# Altium schematic sheet miscellaneous.SchDoc
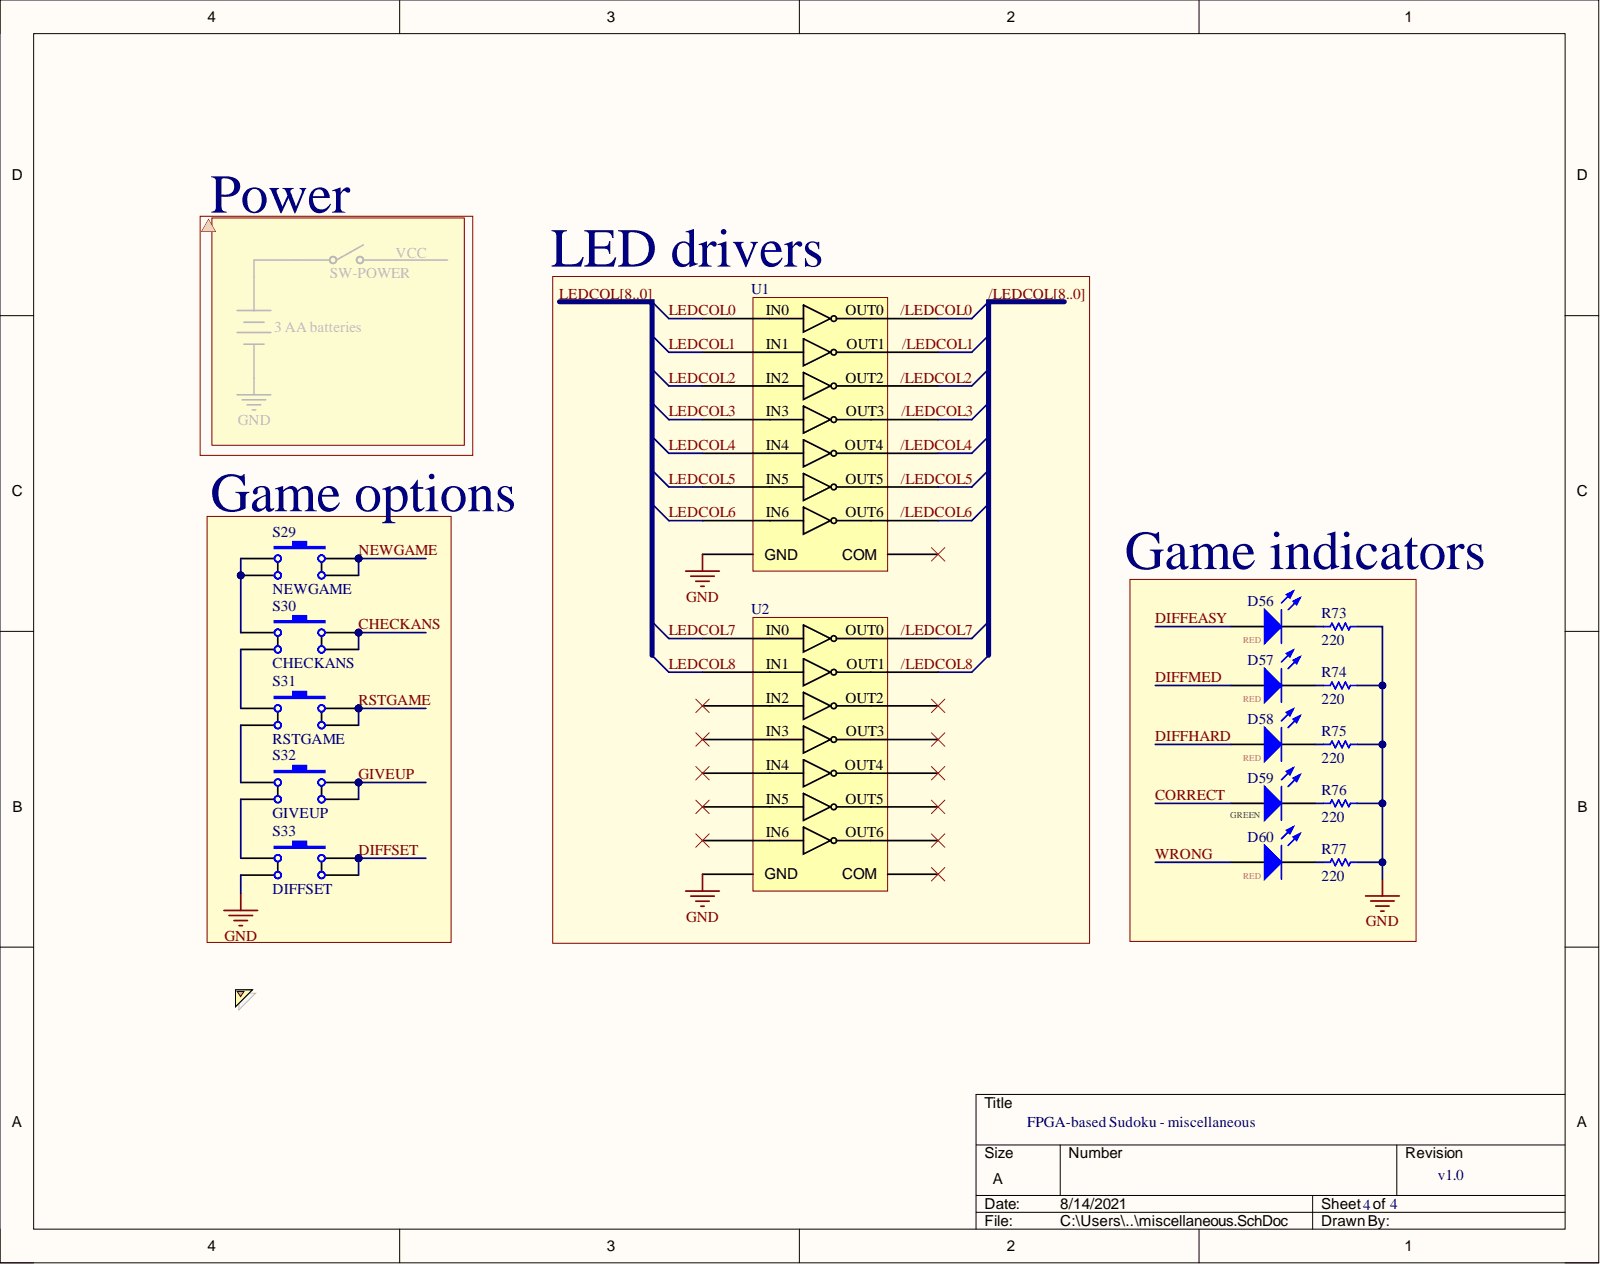
[diagram p0: miscellaneous.SchDoc]

## Parts
D56  RED  [RED]
D57  RED  [RED]
D58  RED  [RED]
D59  GREEN  [green]
D60  RED  [RED]
R73  value 220  [SMD-0805-RES]  (hidden comment: Res1)
R74  value 220  [SMD-0805-RES]  (hidden comment: Res1)
R75  value 220  [SMD-0805-RES]  (hidden comment: Res1)
R76  value 220  [SMD-0805-RES]  (hidden comment: Res1)
R77  value 220  [SMD-0805-RES]  (hidden comment: Res1)
S29  NEWGAME  [SW_1825910-6-4]
S30  CHECKANS  [SW_1825910-6-4]
S31  RSTGAME  [SW_1825910-6-4]
S32  GIVEUP  [SW_1825910-6-4]
S33  DIFFSET  [SW_1825910-6-4]
U1  (no value)  [DIL16]
U2  (no value)  [DIL16]

## Nets
GND: R73.2 R74.2 R75.2 R76.2 R77.2 S33.4 U1.8(GND) U2.8(GND)
/LEDCOL0: U1.16(OUT0)  [also labelled /LEDCOL]
/LEDCOL1: U1.15  [also labelled /LEDCOL]
/LEDCOL2: U1.14(OUT2)  [also labelled /LEDCOL]
/LEDCOL3: U1.13(OUT3)  [also labelled /LEDCOL]
/LEDCOL4: U1.12  [also labelled /LEDCOL]
/LEDCOL5: U1.11(OUT5)  [also labelled /LEDCOL]
/LEDCOL6: U1.10  [also labelled /LEDCOL]
/LEDCOL7: U2.16(OUT0)  [also labelled /LEDCOL]
/LEDCOL8: U2.15  [also labelled /LEDCOL]
CHECKANS: S30.1 S30.2
CORRECT: D59.1
DIFFEASY: D56.1
DIFFHARD: D58.1
DIFFMED: D57.1
DIFFSET: S33.1 S33.2
GIVEUP: S32.1 S32.2
LEDCOL0: U1.6(IN0)  [also labelled LEDCOL]
LEDCOL1: U1.5  [also labelled LEDCOL]
LEDCOL2: U1.4(IN2)  [also labelled LEDCOL]
LEDCOL3: U1.3(IN3)  [also labelled LEDCOL]
LEDCOL4: U1.2  [also labelled LEDCOL]
LEDCOL5: U1.1(IN5)  [also labelled LEDCOL]
LEDCOL6: U1.7  [also labelled LEDCOL]
LEDCOL7: U2.1(IN0)  [also labelled LEDCOL]
LEDCOL8: U2.2  [also labelled LEDCOL]
NEWGAME: S29.1 S29.2
RSTGAME: S31.1 S31.2
WRONG: D60.1
NetD56_2: D56.2 R73.1
NetD57_2: D57.2 R74.1
NetD58_2: D58.2 R75.1
NetD59_2: D59.2 R76.1
NetD60_2: D60.2 R77.1
NetS29_3: S29.3 S29.4 S30.3
NetS30_4: S30.4 S31.3
NetS31_4: S31.4 S32.3
NetS32_4: S32.4 S33.3

Not connected: U1 9(COM); U2 3 4(IN3) 5 6 7 9(COM) 10 11 12 13(OUT3) 14

## Library data of the parts
D56-D60 = LED1: Typical RED GaAs LED; Component Kind: Standard; LatestRevisionDate: 08-Jul-2005; LatestRevisionNote: Mech Layer 1 removed.; Library Name: Miscellaneous Devices.IntLib; PackageDescription: LED; 2 Leads; PackageReference: LED-1; Published: 8-Jun-2000; Simulation: LED1; Publisher: Altium Limited
R73-R77 = Res1: Resistor; Component Kind: Standard; LatestRevisionDate: 17-Jul-2002; LatestRevisionNote: Re-released for DXP Platform.; Library Name: Miscellaneous Devices.IntLib; PackageDescription: Axial Device, Thru-Hole; 2 Leads; 0.3 in Pin Spacing; PackageReference: AXIAL-0.3; Published: 8-Jun-2000; Signal Integrity: Res; Simulation: RESISTOR; Publisher: Altium Limited
S29-S33 = SW-PB: Switch; Component Kind: Standard; LatestRevisionDate: 17-Jul-2002; LatestRevisionNote: Re-released for DXP Platform.; Library Name: tactile_button.SchLib; PackageDescription: Switch; 2 Leads; PackageReference: SPST-2; Published: 24-Mar-1999; Publisher: Altium Limited
U1 U2 = ULN2003A: Component Kind: Standard; Library Name: uln2003a.SchLib
